# Altium schematic sheet cwh_backpanel.SchDoc
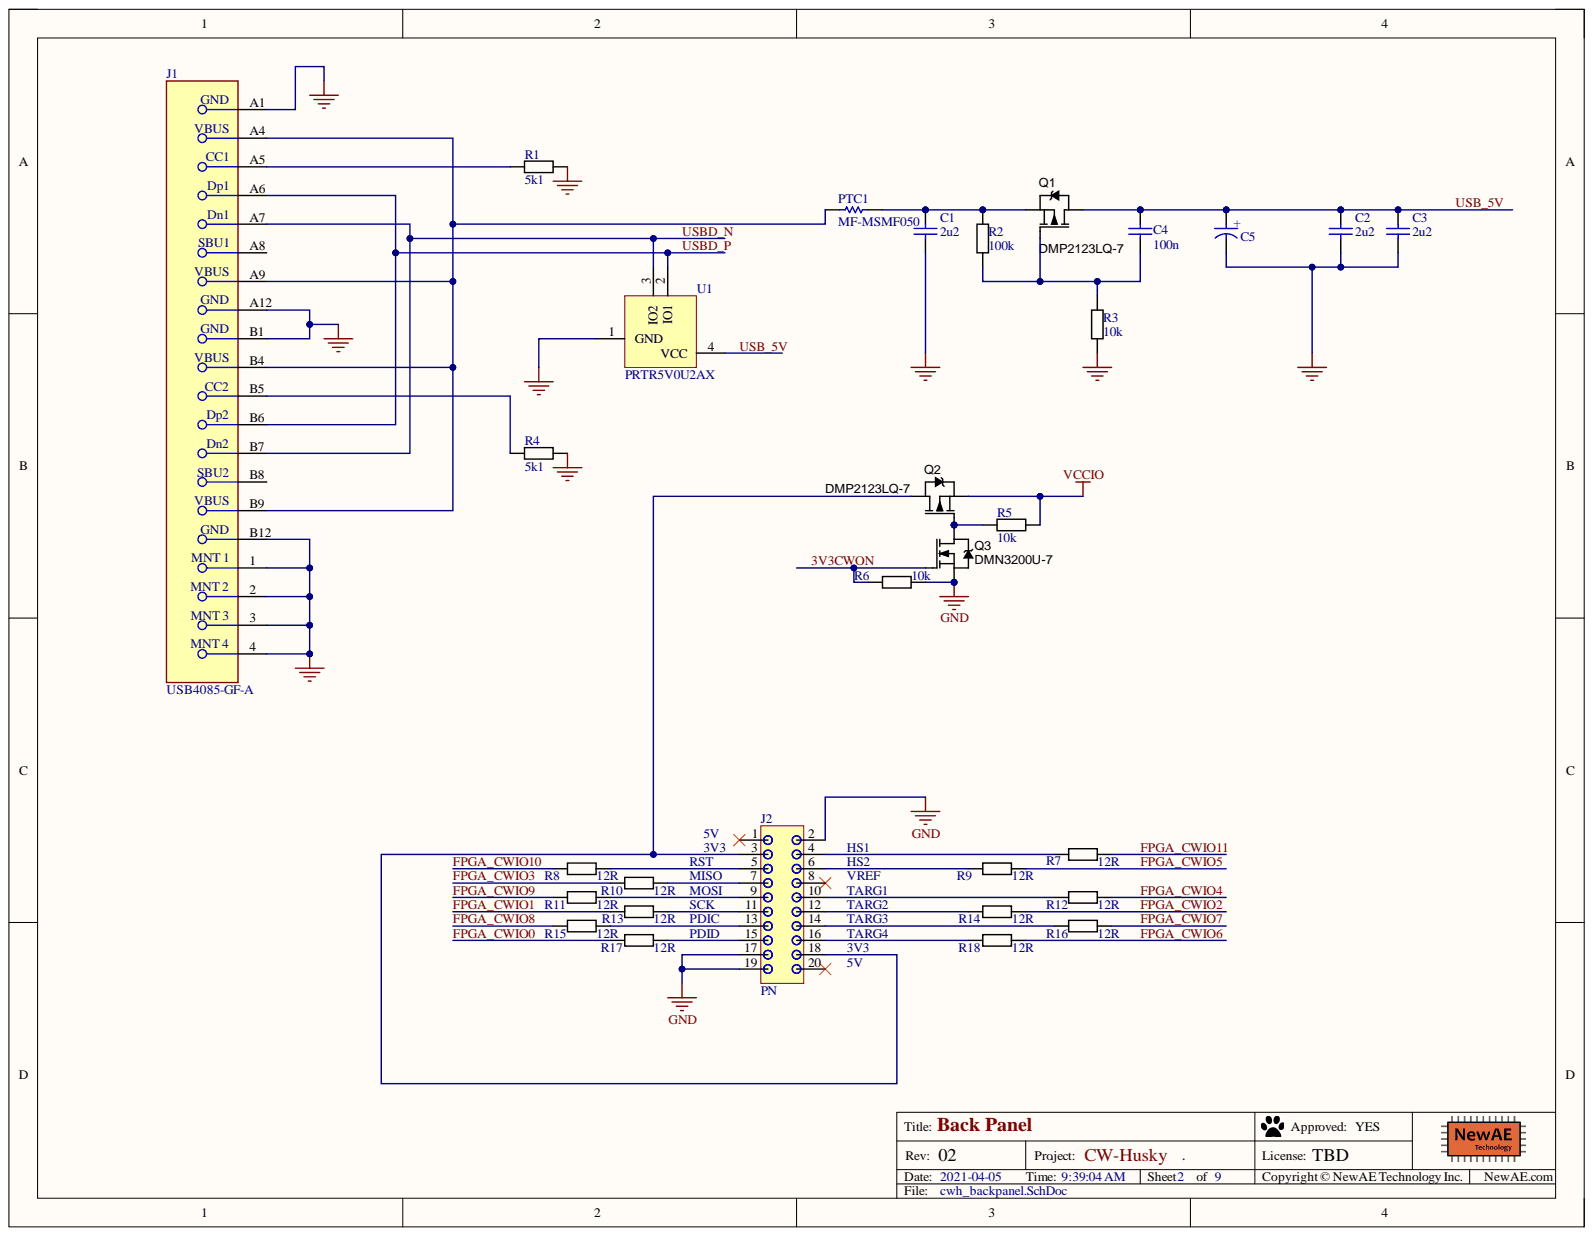
[diagram p0: cwh_backpanel.SchDoc]

## Parts
C1  2u2  [0603]
C2  2u2  [0603]
C3  2u2  [0603]
C4  100n  [0402]
C5  680uF  [Cap_16v_680uF]  (value not drawn)
J1  USB4085-GF-A  [FP-USB4085-GF-A-MFG]
J2  PN  [CON-20HDR-EDGEMOUNT]
PTC1  MF-MSMF050  [BOUR-MF-MSMF050-2_V]
Q1  DMP2123LQ-7  [SOT23]
Q2  DMP2123LQ-7  [SOT23]
Q3  DMN3200U-7  [SOT23]
R1  5k1  [0603]
R2  100k  [0402]
R3  10k  [0402]
R4  5k1  [0603]
R5  10k  [0402]
R6  10k  [0402]
R7  12R  [0402]
R8  12R  [0402]
R9  12R  [0402]
R10  12R  [0402]
R11  12R  [0402]
R12  12R  [0402]
R13  12R  [0402]
R14  12R  [0402]
R15  12R  [0402]
R16  12R  [0402]
R17  12R  [0402]
R18  12R  [0402]
U1  PRTR5V0U2AX  [SOT143B]

## Nets
GND: C1.2 C2.2 C3.2 C5.2 J1.1 J1.2 J1.3 J1.4 J1.A1 J1.A12 J1.B1 J1.B12 J2.2 J2.17 J2.19 Q3.2 R1.2 R3.2 R4.2 R6.2 U1.1(GND)
VCCIO: Q2.2 R5.2
3V3CWON: Q3.1 R6.1
FPGA_CWIO0: R17.1
FPGA_CWIO1: R13.1
FPGA_CWIO2: R14.2
FPGA_CWIO3: R10.1
FPGA_CWIO4: R12.2
FPGA_CWIO5: R9.2
FPGA_CWIO6: R18.2
FPGA_CWIO7: R16.2
FPGA_CWIO8: R15.1
FPGA_CWIO9: R11.1
FPGA_CWIO10: R8.1
FPGA_CWIO11: R7.2
USB_5V: C2.1 C3.1 C4.1 C5.1 Q1.3 U1.4(VCC)
USBD_N: J1.A7 J1.B7 U1.3(IO2)
USBD_P: J1.A6 J1.B6 U1.2(IO1)
NetC1_1: C1.1 PTC1.2 Q1.2 R2.1
NetC4_2: C4.2 Q1.1 R2.2 R3.1
NetJ1_A4: J1.A4 J1.A9 J1.B4 J1.B9 PTC1.1
NetJ1_A5: J1.A5 R1.1
NetJ1_B5: J1.B5 R4.1
NetJ2_3: J2.3 J2.18 Q2.3
NetJ2_4: J2.4 R7.1
NetJ2_5: J2.5 R8.2
NetJ2_6: J2.6 R9.1
NetJ2_7: J2.7 R10.2
NetJ2_9: J2.9 R11.2
NetJ2_10: J2.10 R12.1
NetJ2_11: J2.11 R13.2
NetJ2_12: J2.12 R14.1
NetJ2_13: J2.13 R15.2
NetJ2_14: J2.14 R16.1
NetJ2_15: J2.15 R17.2
NetJ2_16: J2.16 R18.1
NetQ2_1: Q2.1 Q3.3 R5.1

Not connected: J1 A8 B8; J2 1 8 20

## Library data of the parts
C1-C3 = CCHIP-2u2-0603: 2u2, 0603; Manufacture 1: Samsung; Manufacture Part Number 1: CL10B225KP8NNNC; Case-Metric: 1608; Component Group: Capacitor; Database Table Name: main; Rated Voltage: 10VDC; Case-EIA: 0603; Component Kind: Standard; Component Type: Standard; Library Name: NewAE_AltiumLib.DBLib; Mounting Technology: SMT; Part Number: CCHIP-2u2-0603; Pin Count: 2; Supplier 1: Digi-Key; Supplier Part Number 1: 1276-1134-2-ND; Value: 2u2
C4 = CCHIP-100n-0402: 100n, 0402; Manufacture Part Number 1: CGA2B3X7R1V104K050BB; Component Group: Capacitor; Database Table Name: main; Case-EIA: 0402; Component Kind: Standard; Component Type: Standard; Library Name: NewAE_AltiumLib.DBLib; Mounting Technology: SMT; Part Number: CCHIP-100n-0402; Pin Count: 2; Supplier 1: Digi-Key; Supplier Part Number 1: 445-6901-1-ND; Value: 100n
C5 = Cap_16v_680uF: Aluminum electrolytic 680uF, 8x16mm, radial; Component Group: Capacitor; Database Table Name: main; PP Material Stack: 0; Component Kind: Standard; Component Type: Standard; Library Name: NewAE_AltiumLib.DBLib; Mounting Technology: Through-hole; Part Number: Cap_16v_680uF; Pin Count: 2; PP Rotation: 0; Value: 680uF
J1 = CMP-196119-000001-1: CONN RCPT USB2.0 TYPEC 16POS; Manufacturer: Global Connector Technology; Conn Orientation: Right Angle; Current Rating: 4.25A; Is Female: yes; Number Of Contacts: 16; Pitch: 0.85mm; Shielding: true; Voltage Rating: 20V; Automotive: No; Category: Conn; Component Kind: Standard; ComponentLink2Description: Datasheet; ComponentLink2URL: https://gct.co/files/drawings/usb4085.pdf; Contact Material: Gold,Nickel; Device Class L1: Connectors; Device Class L2: USB Connectors; Device Class L3: unset; Digikey Description: CONN RCPT USB2.0 TYPEC 16POS; Height: 3.66mm; Lead Free: Yes; Library Name: Altium Content Vault; Manufacturer Part Number: USB4085-GF-A; Octopart: https://octopart.com/usb4085-gf-a-global+connector+technology-94773699; Package: 0; RoHS: TRUE; Temperature: -40°C to +85°C; Temperature Range High: +85°C; Temperature Range Low: -40°C; Usb Standard: USB 2.0
J2 = CON20HDR-EDGE: Component Group: Connector; Database Table Name: main; PP Material Stack: 0; Component Kind: Standard; Component Type: Standard; Library Name: NewAE_AltiumLib.DBLib; Part Number: CON20HDR-EDGE; Pin Count: 20; PP Rotation: 0; Supplier 1: Digi-Key; Supplier Part Number 1: S9172-ND
PTC1 = CMP-0280-00002-2: MF-MSMF Series - PTC Resettable Fuse, 15 V Max, 0.15 Ohm Min, -40 to 85 degC, RoHS, Reel; Manufacturer: Bourns; Current Rating (Max): 100 A; Hold Current: 0.5 A; Trip Current: 1 A; Voltage DC (Max): 15 V; Component Kind: Standard; ComponentLink1Description: Manufacturer URL; ComponentLink1URL: http://www.bourns.com/; ComponentLink2Description: Datasheet; ComponentLink2URL: http://www.bourns.com/data/global/pdfs/mfmsmf.pdf; ComponentLink3Description: Package Specification; ComponentLink3URL: http://www.bourns.com/data/global/pdfs/mfmsmf.pdf; DatasheetVersion: Rev. AD; Library Name: Altium Content Vault; Mounting Technology: Surface Mount; Operating Temperature Range: -40 to 85 degC; PackageDescription: 2-Pin SMD, Body 4.55 x 3.24 mm; PackageReference: MF-MSMF050; Packing: Reel; PartNumber: MF-MSMF050; Resistance (Min): 0.15 Ohm; RoHS: TRUE; Signal Integrity: Guessed Model; Supplier 1: Digi-Key; Supplier Part Number 1: MF-MSMF050-2CT-ND
Q1 Q2 = DMP2123LQ-7: P-Channel, 3A, Logic Level; Manufacture 1: Diodes Inc.; Component Group: MOSFET; Database Table Name: main; Component Kind: Standard; Component Type: Standard; Library Name: NewAE_AltiumLib.DBLib; Manufacture Part Number 1: DMP2123LQ-7; Mounting Technology: SMT; Part Number: DMP2123LQ-7; Pin Count: 3; Supplier 1: Digi-Key; Supplier Part Number 1: DMP2123LQ-7DICT-ND
Q3 = DMN3200U-7: MOSFET N-CH 30V 2.2A SOT23-3; Manufacture 1: Diodes Inc.; Database Table Name: main; PP Material Stack: 0; Rated Power: 3A; Component Group: MOSFET; Component Kind: Standard; Component Type: Standard; Library Name: NewAE_AltiumLib.DBLib; Manufacture Part Number 1: DMN3200U-7; Mounting Technology: SMT; Part Number: DMN3200U-7; Pin Count: 3; PP Rotation: 0; Rated Voltage: 30V; RoHS: Yes; Supplier 1: Digi-Key; Supplier Part Number 1: DMN3200U-7DICT-ND
R1 R4 = RCHIP-5K1-0603: 5k1 res, 0603, 1%, 1/10W; Manufacture 1: Yageo; Manufacture Part Number 1: RC0603FR-075K1L; Case-Metric: 1608; Component Group: Resistor; Database Table Name: main; Rated Power: 0.1W; Rated Voltage: 75VDC; Case-EIA: 0603; Component Kind: Standard; Component Type: Standard; Library Name: NewAE_AltiumLib.DBLib; Mounting Technology: SMT; Part Number: RCHIP-5K1-0603; Pin Count: 2; Supplier 1: Digi-Key; Supplier Part Number 1: 311-5.10KHRCT-ND; Temperature Range: -55C to 155C; Tolerance: 1%; Value: 5k1
R2 = RCHIP-100K-0402: 100k res, 0402, 1%, 1/16W; Manufacture 1: Yageo; Manufacture Part Number 1: RC0402FR-07100KL; Case-Metric: 1005; Component Group: Resistor; Database Table Name: main; Rated Power: 0.063W; Rated Voltage: 75VDC; Case-EIA: 0402; Component Kind: Standard; Component Type: Standard; Library Name: NewAE_AltiumLib.DBLib; Mounting Technology: SMT; Part Number: RCHIP-100K-0402; Pin Count: 2; Supplier 1: Digi-Key; Supplier Part Number 1: 311-100KLRCT-ND; Temperature Range: -55C to 155C; Tolerance: 1%; Value: 100k
R3 R5 R6 = RCHIP-10K-0402: 10k res, 0402, 1%, 1/16W; Manufacture 1: Yageo; Manufacture Part Number 1: RC0402FR-0710KL; Case-Metric: 1005; Component Group: Resistor; Database Table Name: main; Rated Power: 0.063W; Rated Voltage: 75VDC; Case-EIA: 0402; Component Kind: Standard; Component Type: Standard; Library Name: NewAE_AltiumLib.DBLib; Mounting Technology: SMT; Part Number: RCHIP-10K-0402; Pin Count: 2; Supplier 1: Digi-Key; Supplier Part Number 1: 311-10.0KLRCT-ND; Temperature Range: -55C to 155C; Tolerance: 1%; Value: 10k
R7-R18 = RCHIP-12R-0402: 12R res, 0402, 1%, 1/16W; Manufacture 1: Yageo; Manufacture Part Number 1: RC0402FR-0712RL; Case-Metric: 1005; Component Group: Resistor; Database Table Name: main; Rated Power: 0.063W; Rated Voltage: 75VDC; Case-EIA: 0402; Component Kind: Standard; Component Type: Standard; Library Name: NewAE_AltiumLib.DBLib; Mounting Technology: SMT; Part Number: RCHIP-12R-0402; Pin Count: 2; Supplier 1: Digi-Key; Supplier Part Number 1: YAG2968CT-ND; Temperature Range: -55C to 155C; Tolerance: 1%; Value: 12R
U1 = PRTR5V0U2AX: USB 2.0 high-speed ESD; Component Group: Diode; Database Table Name: main; Component Kind: Standard; Component Type: Standard; Library Name: NewAE_AltiumLib.DBLib; Part Number: PRTR5V0U2AX; Pin Count: 4; Supplier 1: Digi-Key; Supplier Part Number 1: 568-7445-1-ND
